# Altium schematic sheet 06_CAN_Interface.SchDoc(06_CAN_Interface)
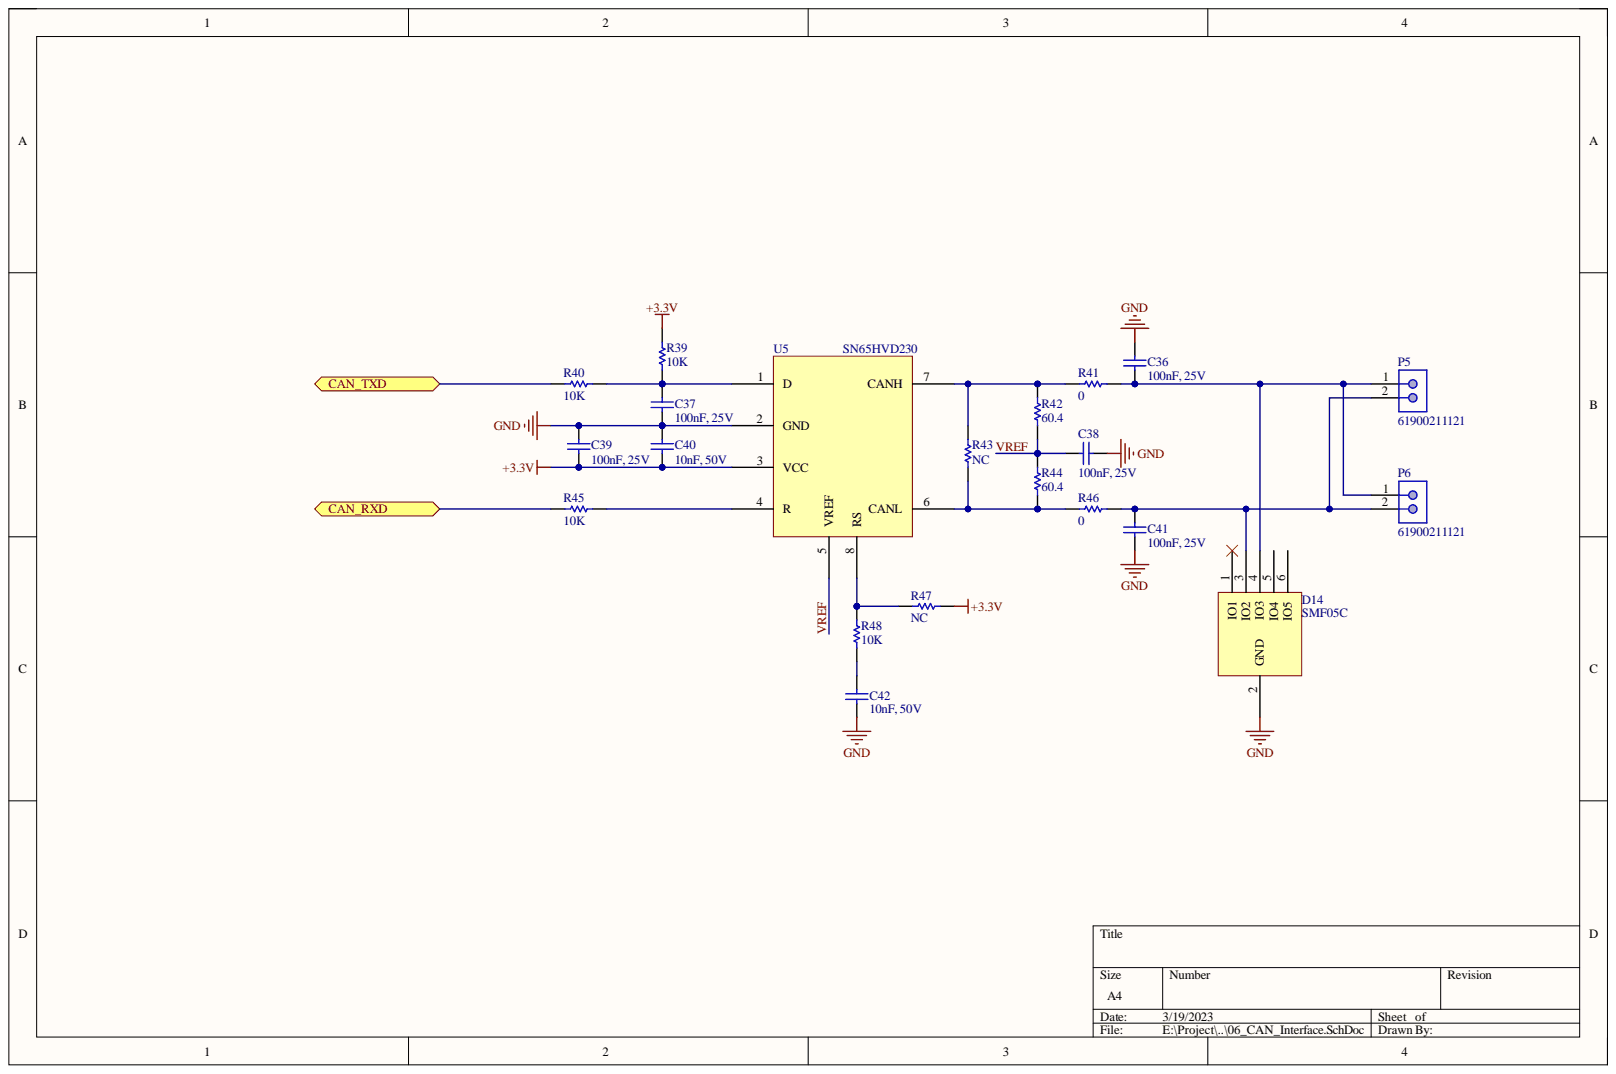
[diagram p0: 06_CAN_Interface.SchDoc]

## Parts
C36  100nF, 25V  [WCAP-CSGP_0603_H=0.8mm_Reflow]
C37  100nF, 25V  [WCAP-CSGP_0603_H=0.8mm_Reflow]
C38  100nF, 25V  [WCAP-CSGP_0603_H=0.8mm_Reflow]
C39  100nF, 25V  [WCAP-CSGP_0603_H=0.8mm_Reflow]
C40  10nF, 50V  [WCAP-CSGP_0603_H=0.8mm_Reflow]
C41  100nF, 25V  [WCAP-CSGP_0603_H=0.8mm_Reflow]
C42  10nF, 50V  [WCAP-CSGP_0603_H=0.8mm_Reflow]
D14  SMF05C  [SOT65P210X110-6N]
P5  61900211121  [61900211121]
P6  61900211121  [61900211121]
R39  value 10K  [RES-SM-0603]  (hidden comment: RESISTOR SMD)
R40  value 10K  [RES-SM-0603]  (hidden comment: RESISTOR SMD)
R41  value 0  [RES-SM-0603]  (hidden comment: RESISTOR SMD)
R42  value 60.4  [RES-SM-0603]  (hidden comment: RESISTOR SMD)
R43  value NC  [RES-SM-0603]  (hidden comment: RESISTOR SMD)
R44  value 60.4  [RES-SM-0603]  (hidden comment: RESISTOR SMD)
R45  value 10K  [RES-SM-0603]  (hidden comment: RESISTOR SMD)
R46  value 0  [RES-SM-0603]  (hidden comment: RESISTOR SMD)
R47  value NC  [RES-SM-0603]  (hidden comment: RESISTOR SMD)
R48  value 10K  [RES-SM-0603]  (hidden comment: RESISTOR SMD)
U5  SN65HVD230  [SOIC127P600X175-8N]

## Ports
CAN_RXD, CAN_TXD

## Nets
+3.3V: C39.1 C40.1 R39.2 R47.1 U5.3(VCC)
GND: C36.2 C37.1 C38.1 C39.2 C40.2 C41.1 C42.1 D14.2(GND) U5.2(GND)
CAN_RXD: R45.2 ; port CAN_RXD
CAN_TXD: R40.2 ; port CAN_TXD
VREF: C38.2 R42.2 R44.1 U5.5(VREF)
NetC36_1: C36.1 D14.4(IO3) P5.1 P6.1 R41.1
NetC37_2: C37.2 R39.1 R40.1 U5.1(D)
NetC41_2: C41.2 D14.3(IO2) P5.2 P6.2 R46.1
NetC42_2: C42.2 R48.1
NetR41_2: R41.2 R42.1 R43.1 U5.7(CANH)
NetR43_2: R43.2 R44.2 R46.2 U5.6(CANL)
NetR45_1: R45.1 U5.4(R)
NetR47_2: R47.2 R48.2 U5.8(RS)

Not connected: D14 1(IO1) 5(IO4) 6(IO5)

## Library data of the parts
C36-C39 C41 = 885012206071: WCAP-CSGP Multilayer Ceramic Chip Capacitor, General Purpose, size 0603, X7R, 100nF, 25V; Manufacturer: Wurth Elektronik; Capacitance Tolerance: +/-10%; Dissipation Factor: #NAME?; Isolation Resistance: >=5GOhm; Rated Voltage: 25VDC; Technical Reference: X7R0603104K025DFCT10000; Capacitance: 100nF; Ceramic Type: X7R; Component Kind: Standard; ComponentLink1Description: Manufacturer; ComponentLink1URL: http://www.we-online.de; ComponentLink2Description: Datasheet; ComponentLink2URL: https://www.we-online.com/catalog/datasheet/885012206071.pdf; Height: 0.8mm; Library Name: Altium_WCAP-CSGP (rev20a).IntLib; Manufacturer Part Number: 885012206071; Mounting Technology: SMT; Operating Temperature: -55 to +125degC; Packaging: Tape and Reel; Published Date: May 2020; Size: 0603
C40 C42 = 885012206089: WCAP-CSGP Multilayer Ceramic Chip Capacitor, General Purpose, size 0603, X7R, 10nF, 50V; Manufacturer: Wurth Elektronik; Capacitance Tolerance: +/-10%; Dissipation Factor: #NAME?; Isolation Resistance: >=10GOhm; Rated Voltage: 50VDC; Technical Reference: X7R0603103K050DFCT10000; Capacitance: 10nF; Ceramic Type: X7R; Component Kind: Standard; ComponentLink1Description: Manufacturer; ComponentLink1URL: http://www.we-online.de; ComponentLink2Description: Datasheet; ComponentLink2URL: https://www.we-online.com/catalog/datasheet/885012206089.pdf; Height: 0.8mm; Library Name: Altium_WCAP-CSGP (rev20a).IntLib; Manufacturer Part Number: 885012206089; Mounting Technology: SMT; Operating Temperature: -55 to +125degC; Packaging: Tape and Reel; Published Date: May 2020; Size: 0603
P5 P6 = 61900211121: WR-WTB THT Male Vertical Locking Header, pitch 2.54mm, 2p; Contact Resistance: 20mOhm; Pin Number: 2; Rated Current: 3A; Withstanding Voltage: 1000VAC; Working Voltage: 250VAC; Component Kind: Standard; ComponentLink1Description: Manufacturer; ComponentLink1URL: http://www.we-online.de; ComponentLink2Description: Datasheet; ComponentLink2URL: https://www.we-online.com/catalog/datasheet/61900211121.pdf; Gender: Male; Library Name: 653008114822_Altium_WR-WTB_rev20a.IntLib; Mounting Technology: THT; Operating Temperature: -40 to +105degC; Packaging: Bag; Part Number: 61900211121; Pitch: 2.54mm; Published Date: January 2020; Type: Vertical; Publisher: Wurth Elektronik
R39-R48 = RESISTOR SMD: Resistor; Component Kind: Standard; Library Name: PIF_Library.IntLib
D14 = SMF05C: Component Kind: Standard; Library Name: MiclsLib.IntLib
U5 = SN65HVD230: Component Kind: Standard; Library Name: MiclsLib.IntLib
